# Altium schematic sheet SENSING.SchDoc (continued)

## Library data of the parts
C1 C3 C5 C6 C8 C10 C12-C14 C17 C18 C22 C23 C27 C28 = CL05A104MP5NNNC: CAP CER 0.1uF 10V X5R 20% SMD 0402 85C Paper T/R; Manufacturer: Samsung; Capacitance: 100nF; Case/Package: 0402; Case Code (Imperial): 0402; Case Code (Metric): 1005; Component Kind: Standard; ComponentLink1Description: https://media.digikey.com/pdf/Data%20Sheets/Samsung%20PDFs/CL_Series_MLCC_ds.pdf; ComponentLink1URL: https://media.digikey.com/pdf/Data%20Sheets/Samsung%20PDFs/CL_Series_MLCC_ds.pdf; Depth: 0.5mm; Dielectric: X5R; Height: 0.5mm; Lead Free: Lead Free; Length: 1mm; Library Name: EEG_SYMBOLS.SchLib; Manufacturer Part Number: CL05A104MP5NNNC; Max Operating Temperature: 85°C; Min Operating Temperature: -55°C; Mount: Surface Mount; Packaging: Tape and Reel; Pricing 1: 1=0.1, 10=0.024, 100=0.0106, 500=0.0076, 1000=0.006, 2500=0.0054, 5000=0.005 (USD); Radiation Hardening: No; Stock 1: 100000; Supplier 1: Digi-Key; Supplier Part Number 1: 1276-1443-1-ND; Thickness: 0.022inch; Tolerance: 20%; Voltage Rating (DC): 10V; Width: 0.02inch
C2 C4 C7 C9 C11 C15 C20 C21 C24 C26 C30 = CL05A105MP5NNNC: CAP CER 1uF 10V X5R 20% SMD 0402 85C Paper T/R; Manufacturer: Samsung; Capacitance: 1uF; Case/Package: 0402; Case Code (Imperial): 0402; Case Code (Metric): 1005; Component Kind: Standard; ComponentLink1Description: https://media.digikey.com/pdf/Data%20Sheets/Samsung%20PDFs/CL_Series_MLCC_ds.pdf; ComponentLink1URL: https://media.digikey.com/pdf/Data%20Sheets/Samsung%20PDFs/CL_Series_MLCC_ds.pdf; Depth: 0.5mm; Dielectric: X5R; Height: 0.5mm; Lead Free: Lead Free; Length: 1mm; Library Name: EEG_SYMBOLS.SchLib; Manufacturer Part Number: CL05A105MP5NNNC; Max Operating Temperature: 85°C; Min Operating Temperature: -55°C; Mount: Surface Mount; Package Quantity: 1; Packaging: Tape and Reel; Pricing 1: 1=0.1, 10=0.031, 100=0.014, 500=0.01, 1000=0.0079, 2500=0.0072, 5000=0.0066 (USD); Radiation Hardening: No; Stock 1: 51298; Supplier 1: Digi-Key; Supplier Part Number 1: 1276-1448-1-ND; Thickness: 0.022inch; Tolerance: 20%; Voltage Rating (DC): 10V; Width: 0.02inch
C16 C19 C25 C29 = CL21A226KPCLRNC: CAP CER 22uF 10V X5R 10% SMD 0805 85C Cardboard T/R; Manufacturer: Samsung; Capacitance: 22uF; Case/Package: 0805; Case Code (Imperial): 0805; Case Code (Metric): 2012; Component Kind: Standard; ComponentLink1Description: https://www.verical.com/server-webapp/html/getDatasheet?partID=781772; ComponentLink1URL: https://www.verical.com/server-webapp/html/getDatasheet?partID=781772; Depth: 1.25mm; Dielectric: X5R; Lead Free: Lead Free; Length: 2mm; Library Name: EEG_SYMBOLS.SchLib; Manufacturer Part Number: CL21A226KPCLRNC; Max Operating Temperature: 85°C; Min Operating Temperature: -55°C; Mount: Surface Mount; Packaging: Tape and Reel; Pricing 1: 1=0.38, 10=0.26, 100=0.1471, 500=0.1039, 1000=0.0909 (USD); Radiation Hardening: No; Stock 1: 40000; Supplier 1: Digi-Key; Supplier Part Number 1: 1276-6786-1-ND; Thickness: 0.037inch; Tolerance: 10%; Voltage Rating: 10V; Width: 0.049inch
C31 C32 = CL05C180JB5NNNC: CAP CER 18pF 50V C0G 5% SMD 0402 125C Paper T/R; Manufacturer: Samsung; Material: Ceramic; Temperature Coefficient: 300ppm/°C; Capacitance: 18pF; Case/Package: 0402; Case Code (Imperial): 0402; Case Code (Metric): 1005; Component Kind: Standard; ComponentLink1Description: https://www.verical.com/server-webapp/html/getDatasheet?partID=482699; ComponentLink1URL: https://www.verical.com/server-webapp/html/getDatasheet?partID=482699; ComponentLink2Description: https://www.distrelec.biz/Web/Downloads/_e/ng/MLCC_CatalogDownload2013_ENG.pdf?mime=application%2Fpdf; ComponentLink2URL: https://www.distrelec.biz/Web/Downloads/_e/ng/MLCC_CatalogDownload2013_ENG.pdf?mime=application%2Fpdf; ComponentLink3Description: https://produktinfo.conrad.com/datenblaetter/450000-474999/458675-da-01-en-VS_KONDENSATOR_0402.pdf; ComponentLink3URL: https://produktinfo.conrad.com/datenblaetter/450000-474999/458675-da-01-en-VS_KONDENSATOR_0402.pdf; Contact Plating: Tin; Depth: 0.5mm; Dielectric: C0G; Height: 0.5mm; Length: 1mm; Library Name: EEG_SYMBOLS.SchLib; Manufacturer Part Number: CL05C180JB5NNNC; Max Operating Temperature: 125°C; Min Operating Temperature: -55°C; Mount: Surface Mount; Package Quantity: 1; Packaging: Tape and Reel; Pricing 1: 1=0.1, 10=0.033, 100=0.0148, 500=0.0105, 1000=0.0083, 2500=0.0075, 5000=0.0069 (USD); Radiation Hardening: No; Stock 1: 42154; Supplier 1: Digi-Key; Supplier Part Number 1: 1276-1140-1-ND; Thickness: 0.022inch; Tolerance: 5%; Voltage: 50V; Voltage Rating: 50V; Width: 0.02inch
D1 D3 = LTST-C191KGKT: LED GREEN CLEAR 0603 SMD; Manufacturer: Vishay Lite-On; Dominant Wavelength: 571nm; Forward Current: 30mA; Forward Voltage: 2V; Lens Colour: Clear,Colorless; Lens Style: Clear,Diffused,Colorless,Rectangular; Luminous Intensity: 35mcd; Number of Elements: 1; Power Dissipation: 75mW; Test Current: 20mA; Viewing Angle: 130°; Wavelength: 574nm; Case/Package: 0603; Case Code (Imperial): 0603; Case Code (Metric): 1608; Colour: Green; Component Kind: Standard; ComponentLink1Description: https://datasheet.ciiva.com/12400/100-ltst-c191kgkt-53586-12400818.pdf; ComponentLink1URL: https://datasheet.ciiva.com/12400/100-ltst-c191kgkt-53586-12400818.pdf; ComponentLink2Description: https://datasheet.ciiva.com/2109/s-110-ltst-c191kgkt-2109973.pdf; ComponentLink2URL: https://datasheet.ciiva.com/2109/s-110-ltst-c191kgkt-2109973.pdf; ComponentLink3Description: https://datasheet.ciiva.com/2109/s-110-ltst-c191kgkt-2109974.pdf; ComponentLink3URL: https://datasheet.ciiva.com/2109/s-110-ltst-c191kgkt-2109974.pdf; ComponentLink4Description: https://datasheet.ciiva.com/2109/s-110-ltst-c191kgkt-2109975.pdf; ComponentLink4URL: https://datasheet.ciiva.com/2109/s-110-ltst-c191kgkt-2109975.pdf; ComponentLink5Description: https://datasheet.ciiva.com/15223/getdatasheetpartid-103913-15223276.pdf; ComponentLink5URL: https://datasheet.ciiva.com/15223/getdatasheetpartid-103913-15223276.pdf; ComponentLink6Description: https://datasheet.ciiva.com/11773/0900766b80dc02b1-11773629.pdf; ComponentLink6URL: https://datasheet.ciiva.com/11773/0900766b80dc02b1-11773629.pdf; ComponentLink7Description: https://datasheet.ciiva.com/16215/ltst-c191kgkt-16215151.pdf; ComponentLink7URL: https://datasheet.ciiva.com/16215/ltst-c191kgkt-16215151.pdf; Depth: 0.8mm; Height: 0.55mm; Illumination Colour: Green; Lead Free: Lead Free; Length: 1.6mm; Lens Transparency: Clear; Library Name: EEG_SYMBOLS.SchLib; Manufacturer Part Number: LTST-C191KGKT; Max Operating Temperature: 85°C; Min Operating Temperature: -55°C; Mount: Surface Mount; Number of LEDs: 1; Number of Pins: 2; Package Quantity: 1; Packaging: Tape and Reel; Power Rating: 75mW; Pricing 1: 1=0.29, 10=0.211, 25=0.1556, 100=0.1111, 250=0.0756, 500=0.0667, 1000=0.0522, 2500=0.0511 (USD); Radiation Hardening: No; Stock 1: 1301664; Supplier 1: Digi-Key; Supplier Part Number 1: 160-1446-6-ND; Width: 0.8mm
D2 D4 = LTST-C191TBKT-5A: LED BLUE CLEAR 0603 SMD; Manufacturer: Vishay Lite-On; Dominant Wavelength: 473nm; Forward Current: 20mA; Forward Voltage: 2.8V; Lens Colour: Colorless; Lens Style: Diffused,Colorless,Rectangular; Luminous Intensity: 15mcd; Number of LEDs: 1; Test Current: 5mA; Viewing Angle: 130°; Voltage: 29V; Case/Package: 0603; Case Code (Imperial): 0603; Case Code (Metric): 1608; Colour: Blue; Component Kind: Standard; ComponentLink1Description: http://components.arrow.com/part/dataview/52218576S9813001N1331; ComponentLink1URL: http://components.arrow.com/part/dataview/52218576S9813001N1331; ComponentLink2Description: http://optoelectronics.liteon.com/zh-cn/api/DwonloadFileHandler.ashx?txtSpecNo=DS22-2003-020&txtPartNo=LTST-C191TBKT-5A; ComponentLink2URL: http://optoelectronics.liteon.com/zh-cn/api/DwonloadFileHandler.ashx?txtSpecNo=DS22-2003-020&txtPartNo=LTST-C191TBKT-5A; Height: 0.55mm; Illumination Colour: Blue; Length: 1.6mm; Lens Transparency: Clear; Library Name: EEG_SYMBOLS.SchLib; Manufacturer Part Number: LTST-C191TBKT-5A; Max Operating Temperature: 80°C; Min Operating Temperature: -20°C; Mount: Surface Mount; Package Quantity: 5000; Packaging: Tape and Reel; Pricing 1: 1=0.52, 10=0.376, 25=0.2884, 100=0.1881, 250=0.138, 500=0.117, 1000=0.0961, 2500=0.0836 (USD); Radiation Hardening: No; Stock 1: 2918; Supplier 1: Digi-Key; Supplier Part Number 1: 160-2212-6-ND; Width: 0.8mm
J1 = CONN_10pin: 10 pin header connector; Component Kind: Standard; Library Name: EEG_SYMBOLS.SchLib; Supplier 1: Arrow; Supplier 2: Arrow; Supplier 3: Digi-Key; Supplier Part Number 1: 2698789; Supplier Part Number 2: 2698803S4475693N1004; Supplier Part Number 3: SAM1198-10-ND
J2 = 302-R161: 16 Positions Header, Shrouded Connector 0.100" (2.54mm) Through Hole, Right Angle Gold; Manufacturer: On-Shore Technology; Connector Type: Male Pin; Number of Positions: 16; Pitch: 0.1inch; Colour: Black; Component Kind: Standard; ComponentLink1Description: http://www.on-shore.com/wp-content/uploads/2015/09/302-rxxx.pdf; ComponentLink1URL: http://www.on-shore.com/wp-content/uploads/2015/09/302-rxxx.pdf; Contact Plating: Gold; Library Name: EEG_SYMBOLS.SchLib; Manufacturer Part Number: 302-R161; Mount: Through Hole; Number of Rows: 2; Packaging: Tray; Pricing 1: 1=0.44, 10=0.417, 180=0.3197, 540=0.278, 1080=0.2294, 5040=0.2016, 10080=0.1877 (USD); Row Spacing: 0.1inch; Stock 1: 7509; Supplier 1: Digi-Key; Supplier Part Number 1: ED10535-ND; Termination: Solder
J3 = M20-9990246: CONN_HeaderPins2pos Headers & Wire Housings 02 SIL VERTICAL PIN HEADER TIN; Manufacturer: Harwin; Connector Type: Male Pin; Contact Resistance: 30mR; Current Rating: 3A; Gender: Male; Insulation Resistance: 10GR; Number of Contacts: 2; Pitch: 2.54mm; Voltage Rating (AC): 750V; Case/Package: SIL; Colour: Black; Component Kind: Standard; ComponentLink1Description: https://datasheet.ciiva.com/8046/315376-8046930.pdf; ComponentLink1URL: https://datasheet.ciiva.com/8046/315376-8046930.pdf; ComponentLink2Description: https://datasheet.ciiva.com/6833/m20-999-6833368.pdf; ComponentLink2URL: https://datasheet.ciiva.com/6833/m20-999-6833368.pdf; ComponentLink3Description: https://datasheet.ciiva.com/11745/0900766b81034e61-11745755.pdf; ComponentLink3URL: https://datasheet.ciiva.com/11745/0900766b81034e61-11745755.pdf; ComponentLink4Description: https://datasheet.ciiva.com/22660/1830818-22660571.pdf; ComponentLink4URL: https://datasheet.ciiva.com/22660/1830818-22660571.pdf; ComponentLink5Description: https://datasheet.ciiva.com/26852/getdatasheetpartid-384170-26852203.pdf; ComponentLink5URL: https://datasheet.ciiva.com/26852/getdatasheetpartid-384170-26852203.pdf; Contact Material: Bronze,Brass; Contact Mating Length: 0.24inch; Contact Plating: Tin; Depth: 2.54mm; Housing Colour: Black; Housing Material: Nylon; Length: 5.08mm; Library Name: EEG_SYMBOLS.SchLib; Manufacturer Part Number: M20-9990246; Max Current Rating: 3A; Max Operating Temperature: 105°C; Min Operating Temperature: -40°C; Number of Positions: 2; Number of Rows: 1; Orientation: Straight; Package Quantity: 1000; Packaging: Bulk; Pricing 1: 1=0.11, 10=0.099, 100=0.0609, 500=0.0504, 1000=0.0441, 5000=0.0378, 10000=0.0368 (USD); Radiation Hardening: No; REACH SVHC: No SVHC; Stock 1: 53577; Supplier 1: Digi-Key; Supplier Part Number 1: 952-2262-ND; Termination: Solder
Q1-Q4 = BSS138-7-F: MOSFET N-CH 50V 200MA SOT23-3; Manufacturer: Diodes; Current: 2A; Drain to Source Resistance: 3.5R; Element Configuration: Single; Fall Time: 25ns; Gate to Source Voltage (Vgs): 20V; Input Capacitance: 50pF; Max Power Dissipation: 300mW; Nominal Vgs: 1.2V; Number of Channels: 1; Rise Time: 10ns; Turn-Off Delay Time: 20ns; Case/Package: SOT-23; Component Kind: Standard; ComponentLink1Description: http://www.diodes.com/datasheets/BSS138.pdf; ComponentLink1URL: http://www.diodes.com/datasheets/BSS138.pdf; ComponentLink2Description: http://www.farnell.com/datasheets/1915619.pdf; ComponentLink2URL: http://www.farnell.com/datasheets/1915619.pdf; ComponentLink3Description: https://www.diodes.com/assets/Datasheets/ds30144.pdf; ComponentLink3URL: https://www.diodes.com/assets/Datasheets/ds30144.pdf; ComponentLink4Description: http://www.diodes.com/_files/datasheets/ds30144.pdf; ComponentLink4URL: http://www.diodes.com/_files/datasheets/ds30144.pdf; Contact Plating: Matte,Tin; Continuous Drain Current (ID): 200mA; Current Rating: 200mA; Drain to Source Breakdown Voltage: 50V; Drain to Source Voltage (Vdss): 50V; Height: 1mm; Lead Free: Lead Free; Length: 2.9mm; Library Name: EEG_SYMBOLS.SchLib; Manufacturer Part Number: BSS138-7-F; Max Operating Temperature: 150°C; Min Operating Temperature: -55°C; Mount: Surface Mount; Number of Elements: 1; Number of Pins: 3; On-State Resistance: 3.5R; Package Quantity: 3000; Packaging: Tape and Reel; Power Dissipation: 300mW; Pricing 1: 1=0.23, 10=0.212, 100=0.119, 500=0.0637, 1000=0.0433 (USD); Rds On Max: 3.5R; REACH SVHC: No SVHC; Stock 1: 6200; Supplier 1: Digi-Key; Supplier Part Number 1: BSS138-FDICT-ND; Threshold Voltage: 1.2V; Turn-On Delay Time: 20ns; Voltage: 50V; Voltage Rating (DC): 50V; Weight: 0.000282oz; Width: 1.3mm
R1 R3 R9 R17 R22 R25 R29 R34 R36 = RC0402FR-07100KL: RES SMD 100K OHM 1% 1/16W 0402; Manufacturer: Yageo; Max Power Dissipation: 63mW; Resistance: 100kR; Temperature Coefficient: 100ppm/°C; Voltage Rating: 50V; Case/Package: 0402; Case Code (Imperial): 0402; Case Code (Metric): 1005; Component Kind: Standard; ComponentLink1Description: http://www.yageo.com/documents/recent/PYu-RC_Group_51_RoHS_L_9.pdf; ComponentLink1URL: http://www.yageo.com/documents/recent/PYu-RC_Group_51_RoHS_L_9.pdf; ComponentLink2Description: http://www.farnell.com/datasheets/1018594.pdf; ComponentLink2URL: http://www.farnell.com/datasheets/1018594.pdf; ComponentLink3Description: http://www.yageo.com/documents/recent/PYu-RC_Group_51_RoHS_L_8.pdf; ComponentLink3URL: http://www.yageo.com/documents/recent/PYu-RC_Group_51_RoHS_L_8.pdf; ComponentLink4Description: http://documents.rs-components.com/techlib://itc_doclist_GB.htm?stockno=6185797; ComponentLink4URL: http://documents.rs-components.com/techlib://itc_doclist_GB.htm?stockno=6185797; Composition: Thick Film; Depth: 0.5mm; Features: Moisture Resistant; Height: 0.016inch; Lead Free: Lead Free; Length: 0.039inch; Library Name: EEG_SYMBOLS.SchLib; Manufacturer Part Number: RC0402FR-07100KL; Max Operating Temperature: 155°C; Military Standard: Not; Min Operating Temperature: -55°C; Number of Terminations: 2; Package Quantity: 1; Packaging: Tape and Reel; Power Rating: 63mW; Pricing 1: 1=0.1, 10=0.018, 100=0.0073, 1000=0.0033, 2500=0.0029, 5000=0.0024 (USD); REACH SVHC: No SVHC; Stock 1: 16311362; Supplier 1: Digi-Key; Supplier Part Number 1: 311-100KLRCT-ND; Termination: SMD/SMT; Tolerance: 1%; Width: 0.02inch; Working Voltage: 50V
R2 R7 R16 R27 = RC0402FR-071KL: RES SMD 1K OHM 1% 1/16W 0402; Manufacturer: Yageo; Power Rating: 62.5mW; Resistance: 1kR; Temperature Coefficient: 100ppm/°C; Voltage Rating: 50V; Case/Package: 0402; Case Code (Imperial): 0402; Case Code (Metric): 1005; Component Kind: Standard; ComponentLink1Description: http://documents.rs-components.com/techlib://itc_doclist_GB.htm?stockno=6186122; ComponentLink1URL: http://documents.rs-components.com/techlib://itc_doclist_GB.htm?stockno=6186122; ComponentLink2Description: http://www.mouser.com/ds/2/447/PYu-RC0402_51_RoHS_L_6_r-8721.pdf; ComponentLink2URL: http://www.mouser.com/ds/2/447/PYu-RC0402_51_RoHS_L_6_r-8721.pdf; ComponentLink3Description: http://www.yageo.com/documents/recent/PYu-RC0402_51_RoHS_L_6_r.pdf; ComponentLink3URL: http://www.yageo.com/documents/recent/PYu-RC0402_51_RoHS_L_6_r.pdf; ComponentLink4Description: http://www.farnell.com/datasheets/1018594.pdf; ComponentLink4URL: http://www.farnell.com/datasheets/1018594.pdf; Composition: Thick Film; Depth: 0.5mm; Height: 0.32mm; Lead Free: Lead Free; Length: 1mm; Library Name: EEG_SYMBOLS.SchLib; Manufacturer Part Number: RC0402FR-071KL; Max Operating Temperature: 155°C; Military Standard: Not; Min Operating Temperature: -55°C; Number of Pins: 2; Packaging: Tape and Reel; Pricing 1: 1=0.1, 10=0.018, 100=0.0073, 1000=0.0033, 2500=0.0029, 5000=0.0024 (USD); REACH SVHC: Unknown; Stock 1: 121806164; Supplier 1: Digi-Key; Supplier Part Number 1: 311-1.00KLRCT-ND; Termination: SMD/SMT; Tolerance: 1%; Voltage Rating (DC): 50V; Width: 0.5mm
R4 R10 R19 R31 = RC0402FR-072KL: RES SMD 2K OHM 1% 1/16W 0402; Manufacturer: Yageo; Max Power Dissipation: 63mW; Resistance: 2kR; Temperature Coefficient: 100ppm/°C; Voltage Rating: 50V; Case/Package: 0402; Case Code (Imperial): 0402; Case Code (Metric): 1005; Component Kind: Standard; ComponentLink1Description: http://www.yageo.com/documents/recent/PYu-RC_Group_51_RoHS_L_9.pdf; ComponentLink1URL: http://www.yageo.com/documents/recent/PYu-RC_Group_51_RoHS_L_9.pdf; ComponentLink2Description: http://documents.rs-components.com/techlib://itc_doclist_GB.htm?stockno=6186510; ComponentLink2URL: http://documents.rs-components.com/techlib://itc_doclist_GB.htm?stockno=6186510; ComponentLink3Description: https://www.verical.com/server-webapp/html/getDatasheet?partID=358921; ComponentLink3URL: https://www.verical.com/server-webapp/html/getDatasheet?partID=358921; ComponentLink4Description: http://www.mouser.com/ds/2/447/PYu-RC0402_51_RoHS_L_6_r-8721.pdf; ComponentLink4URL: http://www.mouser.com/ds/2/447/PYu-RC0402_51_RoHS_L_6_r-8721.pdf; ComponentLink5Description: http://www.farnell.com/datasheets/1018594.pdf; ComponentLink5URL: http://www.farnell.com/datasheets/1018594.pdf; ComponentLink6Description: http://www.farnell.com/datasheets/2167052.pdf; ComponentLink6URL: http://www.farnell.com/datasheets/2167052.pdf; Composition: Thick Film; Depth: 0.5mm; Features: Moisture Resistant; Height: 0.016inch; Lead Free: Lead Free; Length: 1mm; Library Name: EEG_SYMBOLS.SchLib; Manufacturer Part Number: RC0402FR-072KL; Max Operating Temperature: 155°C; Military Standard: Not; Min Operating Temperature: -55°C; Number of Terminations: 2; Package Quantity: 1; Packaging: Tape and Reel; Power Rating: 63mW; Pricing 1: 1=0.1, 10=0.018, 100=0.0073, 1000=0.0033, 2500=0.0029, 5000=0.0024 (USD); REACH SVHC: Unknown; Stock 1: 11965319; Supplier 1: Digi-Key; Supplier Part Number 1: 311-2KLRCT-ND; Termination: SMD/SMT; Tolerance: 1%; Voltage Rating (DC): 50V; Width: 0.02inch; Working Voltage: 50V
R5 R6 R11 R12 R21 R23 R24 R32 R33 = RC0402FR-07330KL: RES SMD 330K OHM 1% 1/16W 0402; Manufacturer: Yageo; Max Power Dissipation: 63mW; Resistance: 330kR; Temperature Coefficient: 100ppm/°C; Voltage Rating: 50V; Case/Package: 0402; Case Code (Imperial): 0402; Case Code (Metric): 1005; Component Kind: Standard; ComponentLink1Description: http://www.yageo.com/documents/recent/PYu-RC_Group_51_RoHS_L_9.pdf; ComponentLink1URL: http://www.yageo.com/documents/recent/PYu-RC_Group_51_RoHS_L_9.pdf; ComponentLink2Description: http://www.mouser.com/ds/2/447/PYu-RC0402_51_RoHS_L_6_r-8721.pdf; ComponentLink2URL: http://www.mouser.com/ds/2/447/PYu-RC0402_51_RoHS_L_6_r-8721.pdf; ComponentLink3Description: http://www.farnell.com/datasheets/1018594.pdf; ComponentLink3URL: http://www.farnell.com/datasheets/1018594.pdf; ComponentLink4Description: http://www.yageo.com/documents/recent/PYu-RC0402_51_RoHS_L_6_r.pdf; ComponentLink4URL: http://www.yageo.com/documents/recent/PYu-RC0402_51_RoHS_L_6_r.pdf; Composition: Thick Film; Depth: 0.5mm; Features: Moisture Resistant; Height: 0.016inch; Lead Free: Lead Free; Length: 1mm; Library Name: EEG_SYMBOLS.SchLib; Manufacturer Part Number: RC0402FR-07330KL; Max Operating Temperature: 155°C; Military Standard: Not; Min Operating Temperature: -55°C; Number of Terminations: 2; Package Quantity: 1; Packaging: Tape and Reel; Power Rating: 63mW; Pricing 1: 1=0.1, 10=0.018, 100=0.0073, 1000=0.0033, 2500=0.0029, 5000=0.0024 (USD); REACH SVHC: Unknown; Stock 1: 1854774; Supplier 1: Digi-Key; Supplier Part Number 1: 311-330KLRCT-ND; Termination: SMD/SMT; Tolerance: 1%; Width: 0.02inch
R8 R14 R15 R20 R26 R30 R35 R38 = RC0402FR-071ML: RES SMD 1M OHM 1% 1/16W 0402; Manufacturer: Yageo; Power Rating: 63mW; Resistance: 1MR; Temperature Coefficient: 100ppm/°C; Voltage Rating: 50V; Case/Package: 0402; Case Code (Imperial): 0402; Case Code (Metric): 1005; Component Kind: Standard; ComponentLink1Description: http://www.farnell.com/datasheets/1018594.pdf; ComponentLink1URL: http://www.farnell.com/datasheets/1018594.pdf; ComponentLink2Description: http://www.yageo.com/documents/recent/PYu-RC0402_51_RoHS_L_6_r.pdf; ComponentLink2URL: http://www.yageo.com/documents/recent/PYu-RC0402_51_RoHS_L_6_r.pdf; Composition: Thick Film; Depth: 0.5mm; Height: 0.32mm; Lead Free: Lead Free; Length: 1mm; Library Name: EEG_SYMBOLS.SchLib; Manufacturer Part Number: RC0402FR-071ML; Max Operating Temperature: 155°C; Military Standard: Not; Min Operating Temperature: -55°C; Packaging: Tape and Reel; Pricing 1: 1=0.1, 10=0.018, 100=0.0073, 1000=0.0033, 2500=0.0029, 5000=0.0024 (USD); REACH SVHC: Unknown; Stock 1: 18919667; Supplier 1: Digi-Key; Supplier Part Number 1: 311-1.00MLRCT-ND; Termination: SMD/SMT; Tolerance: 1%
R13 R18 R28 R37 = RMCF0402FT499K: RES SMD 499K 0402 1% 1/16W 100ppm/C Molded SMD Paper T/R; Manufacturer: Stackpole Electronics; Max Power Dissipation: 63mW; Resistance: 499kR; Temperature Coefficient: 100ppm/°C; Case/Package: 0402; Case Code (Imperial): 0402; Case Code (Metric): 1005; Component Kind: Standard; Composition: Thick Film; Contact Plating: Matte,Tin; Features: Automotive AEC-Q200; Height: 0.016inch; Lead Free: Lead Free; Length: 0.039inch; Library Name: EEG_SYMBOLS.SchLib; Manufacturer Part Number: RMCF0402FT499K; Max Operating Temperature: 155°C; Min Operating Temperature: -55°C; Mount: Surface Mount; Number of Terminations: 2; Package Quantity: 10000; Packaging: Tape and Reel; Pricing 1: 1=0.1, 10=0.016, 100=0.0064, 1000=0.0029, 2500=0.0025, 5000=0.0021 (USD); Stock 1: 80000; Supplier 1: Digi-Key; Supplier Part Number 1: RMCF0402FT499KCT-ND; Tolerance: 1%; Width: 0.02inch
R39-R46 = RC0402JR-0710KL: RES SMD 10K OHM 5% 1/16W 0402; Manufacturer: Yageo; Max Power Dissipation: 63mW; Power Rating: 62.5mW; Resistance: 10kR; Temperature Coefficient: 100ppm/°C; Voltage Rating: 50V; Case/Package: 0402; Case Code (Imperial): 0402; Case Code (Metric): 1005; Component Kind: Standard; Composition: Thick Film; Depth: 0.5mm; Features: Moisture Resistant; Height: 0.016inch; Lead Free: Lead Free; Length: 1mm; Library Name: EEG_SYMBOLS.SchLib; Manufacturer Part Number: RC0402JR-0710KL; Max Operating Temperature: 155°C; Military Standard: Not; Min Operating Temperature: -55°C; Number of Terminations: 2; Package Quantity: 1; Packaging: Tape and Reel; Pricing 1: 1=0.1, 10=0.018, 100=0.0073, 1000=0.0033, 2500=0.0029, 5000=0.0024 (USD); REACH SVHC: Unknown; Stock 1: 47303622; Supplier 1: Digi-Key; Supplier Part Number 1: 311-10KJRCT-ND; Termination: SMD/SMT; Tolerance: 5%; Width: 0.02inch
R47 = RC0805FR-07100RL: RES SMD 100 OHM 1% 1/8W 0805; Manufacturer: Yageo; Max Power Dissipation: 125mW; Temperature Coefficient: 100ppm/°C; Voltage Rating: 150V; Case/Package: 0805; Case Code (Imperial): 0805; Case Code (Metric): 2012; Component Kind: Standard; ComponentLink1Description: http://www.farnell.com/datasheets/1697719.pdf; ComponentLink1URL: http://www.farnell.com/datasheets/1697719.pdf; ComponentLink2Description: http://www.yageo.ru/pdf/RC0805.pdf; ComponentLink2URL: http://www.yageo.ru/pdf/RC0805.pdf; ComponentLink3Description: http://www.farnell.com/datasheets/444164.pdf; ComponentLink3URL: http://www.farnell.com/datasheets/444164.pdf; ComponentLink4Description: http://www.yageo.com/documents/recent/PYu-RC_Group_51_RoHS_L_9.pdf; ComponentLink4URL: http://www.yageo.com/documents/recent/PYu-RC_Group_51_RoHS_L_9.pdf; ComponentLink5Description: http://www.farnell.com/datasheets/38627.pdf; ComponentLink5URL: http://www.farnell.com/datasheets/38627.pdf; ComponentLink6Description: http://www.farnell.com/datasheets/1686128.pdf; ComponentLink6URL: http://www.farnell.com/datasheets/1686128.pdf; Composition: Thick Film; Depth: 1.25mm; Features: Moisture Resistant; Height: 0.024inch; Lead Free: Lead Free; Lead Pitch: 0.4mm; Length: 2mm; Library Name: EEG_SYMBOLS.SchLib; Manufacturer Part Number: RC0805FR-07100RL; Max Operating Temperature: 155°C; Military Standard: Not; Min Operating Temperature: -55°C; Number of Pins: 2; Number of Terminations: 2; Package Quantity: 1; Packaging: Tape and Reel; Power Rating: 125mW; Pricing 1: 1=0.1, 10=0.046, 100=0.0186, 1000=0.0084, 2500=0.0073 (USD); REACH SVHC: Unknown; Resistance: 100R; Stock 1: 12008452; Supplier 1: Digi-Key; Supplier Part Number 1: 311-100CRCT-ND; Termination: SMD/SMT; Tolerance: 1%; Voltage Rating (DC): 150V; Width: 0.049inch
R48-R51 = RC0402JR-070RL: RES SMD 0 OHM 1/16W 0402; Manufacturer: Yageo; Max Power Dissipation: 63mW; Power Rating: 62.5mW; Resistance: 0mR; Temperature Coefficient: 200ppm/°C; Tolerance: 5%; Voltage Rating: 50V; Case/Package: 0402; Case Code (Imperial): 0402; Case Code (Metric): 1005; Component Kind: Standard; Composition: Thick Film; Contact Plating: Matte,Tin; Depth: 0.5mm; Features: Moisture Resistant; Height: 0.016inch; Lead Free: Lead Free; Length: 1mm; Library Name: EEG_SYMBOLS.SchLib; Manufacturer Part Number: RC0402JR-070RL; Max Operating Temperature: 155°C; Military Standard: Not; Min Operating Temperature: -55°C; Number of Terminations: 2; Package Quantity: 1; Packaging: Tape and Reel; Pricing 1: 1=0.1, 10=0.017, 25=0.0124, 50=0.0096, 100=0.007, 250=0.0054, 500=0.0043, 1000=0.0032, 5000=0.0023 (USD); Radiation Hardening: No; REACH SVHC: Unknown; Stock 1: 44333412; Supplier 1: Digi-Key; Supplier Part Number 1: 311-0.0JRCT-ND; Termination: SMD/SMT; Width: 0.02inch
R52-R55 = ERJ-2RKF3000X: RES SMD 300R 0402 300 Ohm 1% 1/10W ±100ppm/C Molded SMD Punched Carrier T/R; Manufacturer: Panasonic; Max Power Dissipation: 100mW; Temperature Coefficient: 100ppm/°C; Voltage Rating: 50V; Case/Package: 0402; Case Code (Imperial): 0402; Case Code (Metric): 1005; Component Kind: Standard; ComponentLink1Description: https://www.alliedelec.com/m/d/623f45ff1957d936ff56d46fd1c28cac.pdf; ComponentLink1URL: https://www.alliedelec.com/m/d/623f45ff1957d936ff56d46fd1c28cac.pdf; ComponentLink2Description: http://industrial.panasonic.com/www-data/pdf/AOA0000/AOA0000CE2.pdf; ComponentLink2URL: http://industrial.panasonic.com/www-data/pdf/AOA0000/AOA0000CE2.pdf; ComponentLink3Description: http://www.farnell.com/datasheets/1911175.pdf; ComponentLink3URL: http://www.farnell.com/datasheets/1911175.pdf; ComponentLink4Description: http://components.arrow.com/part/dataview/41791037S8289418N1386; ComponentLink4URL: http://components.arrow.com/part/dataview/41791037S8289418N1386; ComponentLink5Description: http://www.mouser.com/ds/2/315/AOA0000CE2-37512.pdf; ComponentLink5URL: http://www.mouser.com/ds/2/315/AOA0000CE2-37512.pdf; ComponentLink6Description: http://www.farnell.com/datasheets/1694929.pdf; ComponentLink6URL: http://www.farnell.com/datasheets/1694929.pdf; Composition: Thick Film; Contact Plating: Tin; Depth: 0.5mm; Height: 0.35mm; Lead Free: Lead Free; Length: 1mm; Library Name: EEG_SYMBOLS.SchLib; Manufacturer Part Number: ERJ-2RKF3000X; Max Operating Temperature: 155°C; Military Standard: Not; Min Operating Temperature: -55°C; Mount: Surface Mount; Number of Terminations: 2; Package Quantity: 10000; Packaging: Tape and Reel; Power Rating: 100mW; Pricing 1: 1=0.1, 10=0.054, 100=0.0221, 1000=0.0099, 2500=0.0086, 5000=0.0071 (USD); REACH SVHC: No SVHC; Resistance: 300R; Stock 1: 2431619; Supplier 1: Digi-Key; Supplier Part Number 1: P300LCT-ND; Termination: SMD/SMT; Tolerance: 1%; Voltage Rating (DC): 50V; Width: 0.02inch
U1 U3 = NCP551SN30T1G: LDO 3.0V 150mA CMOS w/Enable; Manufacturer: ON Semiconductor; Accuracy: 2%; Dropout Voltage: 40mV; Input Bias Current: 4uA; Max Input Voltage: 12V; Min Input Voltage: 0uV; Min Output Voltage: 3V; Number of Outputs: 1; Output Type: Fixed; Polarity: Positive; Quiescent Current: 8uA; Case/Package: TSOP; Component Kind: Standard; ComponentLink1Description: http://www.onsemi.ru.com/pub/Collateral/NCP551-D.PDF; ComponentLink1URL: http://www.onsemi.ru.com/pub/Collateral/NCP551-D.PDF; ComponentLink2Description: http://www.onsemi.com/pub/Collateral/NCP551-D.PDF; ComponentLink2URL: http://www.onsemi.com/pub/Collateral/NCP551-D.PDF; Contact Plating: Matte,Tin; Halogen Free: Halogen Free; Height: 1mm; Lead Free: Lead Free; Length: 3mm; Library Name: EEG_SYMBOLS.SchLib; Manufacturer Part Number: NCP551SN30T1G; Max Operating Temperature: 85°C; Max Output Current: 150mA; Min Operating Temperature: -40°C; Mount: Surface Mount; Nominal Output Voltage: 3V; Number of Pins: 5; Number of Regulators: 1; Output Current: 150mA; Output Voltage: 3V; Output Voltage Accuracy: 2%; Package Quantity: 1; Packaging: Tape and Reel; Pricing 1: 1=0.54, 10=0.46, 100=0.3432, 500=0.2697, 1000=0.2084 (USD); Radiation Hardening: No; REACH SVHC: No SVHC; Stock 1: 9920; Supplier 1: Digi-Key; Supplier Part Number 1: NCP551SN30T1GOSCT-ND; Width: 1.5mm
U2 = MCP3912A1-E/SS: IC Analog Front End - AFE 4 Chnl Energy Meter Front End 3V SPI/2; Manufacturer: Microchip; Interface: Serial,SPI; Max Supply Voltage: 3.6V; Min Supply Voltage: 2.7V; Number of A/D Converters: 4; Resolution: 24b; Sampling Rate: 125KS/s; Case/Package: SSOP; Component Kind: Standard; Height: 1.85mm; Lead Free: Lead Free; Length: 10.5mm; Library Name: EEG_SYMBOLS.SchLib; Manufacturer Part Number: MCP3912A1-E/SS; Max Operating Temperature: 125°C; Min Operating Temperature: -40°C; Mount: Surface Mount; Number of Channels: 4; Number of Pins: 28; Package Quantity: 47; Packaging: Rail/Tube; Pricing 1: 1=3.33, 25=2.7708, 100=2.5235 (USD); REACH SVHC: No SVHC; Stock 1: 156; Supplier 1: Digi-Key; Supplier Part Number 1: MCP3912A1-E/SS-ND; Width: 5.6mm
U4 U6 U9 U12 = AD8237ARMZ-R7: IC OPAMP INSTR 200KHZ RRO 8MSOP; Manufacturer: Analog Devices; Bandwidth: 20kHz; Common Mode Rejection Ratio: 106dB; Input Bias Current: 250pA; Input Offset Voltage (Vos): 75uV; Max Supply Voltage: 5.5V; Min Supply Voltage: 1.8V; Nominal Supply Current: 115uA; Number of Channels: 1; Output Current per Channel: 4mA; Slew Rate: 0.15V/µs; Voltage Gain: 60dB; -3db Bandwidth: 200kHz; Case/Package: MSOP; Component Kind: Standard; ComponentLink1Description: http://www.analog.com/media/en/technical-documentation/data-sheets/AD8237.pdf; ComponentLink1URL: http://www.analog.com/media/en/technical-documentation/data-sheets/AD8237.pdf; ComponentLink2Description: http://www.analog.com/static/imported-files/data_sheets/AD8237.pdf; ComponentLink2URL: http://www.analog.com/static/imported-files/data_sheets/AD8237.pdf; Contact Plating: Matte,Tin; Lead Free: Contains Lead; Library Name: EEG_SYMBOLS.SchLib; Manufacturer Part Number: AD8237ARMZ-R7; Max Operating Temperature: 125°C; Min Operating Temperature: -40°C; Mount: Surface Mount; Number of Circuits: 1; Number of Elements: 1; Number of Pins: 8; Operating Supply Current: 115uA; Package Quantity: 1; Packaging: Tape and Reel; Pricing 1: 1=2.76, 10=2.476, 100=2.0286, 500=1.7269 (USD); Stock 1: 16545; Supplier 1: Digi-Key; Supplier Part Number 1: AD8237ARMZ-R7CT-ND
U5 = LM7332MAX/NOPB: IC OP AMP R-R I/O DUAL 30V 8SOIC; Manufacturer: TI National Semiconductor; Common Mode Rejection Ratio: 62dB; Gain Bandwidth Product: 21MHz; Input Bias Current: 1uA; Input Offset Voltage (Vos): 2mV; Max Dual Supply Voltage: 16V; Max Supply Voltage: 32V; Min Dual Supply Voltage: 1.25V; Min Supply Voltage: 2.5V; Nominal Supply Current: 2mA; Number of Channels: 2; Output Current: 70mA; Power Supply Rejection Ratio (PSRR): 78dB; Slew Rate: 15.2V/µs; Unity Gain Bandwidth Product: 19.3MHz; Voltage Gain: 77dB; Case/Package: SOIC; Component Kind: Standard; ComponentLink1Description: http://www.ti.com/lit/ds/symlink/lm7332.pdf; ComponentLink1URL: http://www.ti.com/lit/ds/symlink/lm7332.pdf; Contact Plating: Tin; Lead Free: Lead Free; Library Name: EEG_SYMBOLS.SchLib; Manufacturer Part Number: LM7332MAX/NOPB; Max Operating Temperature: 125°C; Min Operating Temperature: -40°C; Mount: Surface Mount; Number of Circuits: 2; Number of Elements: 2; Number of Pins: 8; Operating Supply Current: 2mA; Operating Supply Voltage: 16V; Output Current per Channel: 70mA; Package Quantity: 2500; Packaging: Tape and Reel; Pricing 1: 1=2.67, 10=2.402, 100=1.9306, 500=1.5862, 1000=1.3143 (USD); Radiation Hardening: No; Stock 1: 7321; Supplier 1: Digi-Key; Supplier Part Number 1: 296-35310-1-ND
U7 U8 U10 U11 U13 = MCP6V31T-E/OT: IC OPAMP ZRO-DRFT 300KHZ SOT23-5; Manufacturer: Microchip; Common Mode Rejection Ratio: 120dB; Input Bias Current: 5pA; Input Offset Voltage (Vos): 8uV; Max Supply Voltage: 5.5V; Min Supply Voltage: 1.8V; Nominal Supply Current: 23uA; Number of Amplifiers: 1; Output Current: 21mA; Slew Rate: 0.13V/µs; Voltage Gain: 135dB; Bandwidth: 300kHz; Case/Package: SOT-23; Component Kind: Standard; ComponentLink1Description: http://ww1.microchip.com/downloads/en/DeviceDoc/25127A.pdf; ComponentLink1URL: http://ww1.microchip.com/downloads/en/DeviceDoc/25127A.pdf; ComponentLink2Description: https://www.distrelec.biz/Web/Downloads/_t/ds/MCP6V31_1U_eng_tds.pdf?mime=application%2Fpdf; ComponentLink2URL: https://www.distrelec.biz/Web/Downloads/_t/ds/MCP6V31_1U_eng_tds.pdf?mime=application%2Fpdf; ComponentLink3Description: https://www.verical.com/server-webapp/html/getDatasheet?partID=762349; ComponentLink3URL: https://www.verical.com/server-webapp/html/getDatasheet?partID=762349; ComponentLink4Description: http://ww1.microchip.com/downloads/jp/DeviceDoc/jp557758.pdf; ComponentLink4URL: http://ww1.microchip.com/downloads/jp/DeviceDoc/jp557758.pdf; ComponentLink5Description: http://www.microchip.com/mymicrochip/filehandler.aspx?ddocname=en557758; ComponentLink5URL: http://www.microchip.com/mymicrochip/filehandler.aspx?ddocname=en557758; ComponentLink6Description: http://www.farnell.com/datasheets/1599560.pdf; ComponentLink6URL: http://www.farnell.com/datasheets/1599560.pdf; Gain Bandwidth Product: 300kHz; Height: 1.3mm; Lead Free: Lead Free; Length: 3.1mm; Library Name: EEG_SYMBOLS.SchLib; Manufacturer Part Number: MCP6V31T-E/OT; Max Operating Temperature: 125°C; Min Operating Temperature: -40°C; Number of Channels: 1; Number of Circuits: 1; Number of Elements: 1; Number of Pins: 5; Operating Supply Current: 23uA; Output Current per Channel: 21mA; Package Quantity: 3000; Packaging: Tape and Reel; Power Supply Rejection Ratio (PSRR): 120dB; Pricing 1: 1=1.11, 25=0.9272, 100=0.8446 (USD); Radiation Hardening: No; REACH SVHC: No SVHC; Stock 1: 12497; Supplier 1: Digi-Key; Supplier Part Number 1: MCP6V31T-E/OTCT-ND; Unity Gain Bandwidth Product: 300kHz; Width: 1.8mm
U14 = LM334MX/NOPB: IC CURRENT SOURCE 3% 8SOIC; Manufacturer: TI National Semiconductor; Accuracy: 6%; Forward Current: 5mA; Forward Voltage: 1V; Max Input Voltage: 40V; Max Power Dissipation: 400mW; Max Supply Current: 10mA; Min Supply Voltage: 800mV; Number of Outputs: 1; Output Type: Analog; Output Voltage: 20V; Quiescent Current: 1uA; Reference Type: Adjustable; Case/Package: SOIC; Component Kind: Standard; ComponentLink1Description: https://www.verical.com/server-webapp/html/getDatasheet?partID=629166; ComponentLink1URL: https://www.verical.com/server-webapp/html/getDatasheet?partID=629166; ComponentLink2Description: http://www.ti.com/lit/ds/symlink/lm234.pdf; ComponentLink2URL: http://www.ti.com/lit/ds/symlink/lm234.pdf; ComponentLink3Description: http://www.ti.com/general/docs/lit/getliterature.tsp?genericPartNumber=LM334&&fileType=pdf; ComponentLink3URL: http://www.ti.com/general/docs/lit/getliterature.tsp?genericPartNumber=LM334&&fileType=pdf; ComponentLink4Description: http://www.farnell.com/datasheets/1883087.pdf; ComponentLink4URL: http://www.farnell.com/datasheets/1883087.pdf; Lead Free: Lead Free; Library Name: EEG_SYMBOLS.SchLib; Manufacturer Part Number: LM334MX/NOPB; Max Operating Temperature: 85°C; Max Supply Voltage: 40V; Min Input Voltage: 1V; Min Operating Temperature: -40°C; Mount: Surface Mount; Number of Pins: 8; Output Current: 10mA; Package Quantity: 2500; Packaging: Tape and Reel; Pricing 1: 1=0.9, 10=0.802, 100=0.6254, 500=0.5166, 1000=0.4079 (USD); Radiation Hardening: No; REACH SVHC: No SVHC; Stock 1: 58254; Supplier 1: Digi-Key; Supplier Part Number 1: LM334MX/NOPBCT-ND
U15 = MCP4011-103E/SN: IC 10K Digital Potentiometer Single, 2 Wire, Serial,  20%, 1.8 V; Manufacturer: Microchip; Interface: 2-Wire,Serial; Max Supply Current: 45uA; Max Supply Voltage: 5.5V; Memory Type: Volatile; Min Supply Voltage: 1.8V; Number of Circuits: 1; Number of Positions: 64; Operating Supply Voltage: 5V; Resistance: 10kR; Temperature Coefficient: 150ppm/°C; Case/Package: SOIC; Component Kind: Standard; ComponentLink1Description: http://ww1.microchip.com/downloads/en/DeviceDoc/21978c.pdf; ComponentLink1URL: http://ww1.microchip.com/downloads/en/DeviceDoc/21978c.pdf; ComponentLink2Description: https://www.verical.com/server-webapp/html/getDatasheet?partID=431510; ComponentLink2URL: https://www.verical.com/server-webapp/html/getDatasheet?partID=431510; ComponentLink3Description: http://www.microchip.com/mymicrochip/filehandler.aspx?ddocname=en025230; ComponentLink3URL: http://www.microchip.com/mymicrochip/filehandler.aspx?ddocname=en025230; ComponentLink4Description: http://www.microchip.com/mymicrochip/filehandler.aspx?ddocname=en023833; ComponentLink4URL: http://www.microchip.com/mymicrochip/filehandler.aspx?ddocname=en023833; ComponentLink5Description: https://www.distrelec.biz/Web/Downloads/_t/ds/mcp4011_eng_tds.pdf?mime=application%2Fpdf; ComponentLink5URL: https://www.distrelec.biz/Web/Downloads/_t/ds/mcp4011_eng_tds.pdf?mime=application%2Fpdf; ComponentLink6Description: http://www.farnell.com/datasheets/130143.pdf; ComponentLink6URL: http://www.farnell.com/datasheets/130143.pdf; Contact Plating: Matte,Tin; Height: 1.55mm; Lead Free: Lead Free; Length: 5mm; Library Name: EEG_SYMBOLS.SchLib; Manufacturer Part Number: MCP4011-103E/SN; Max Operating Temperature: 125°C; Min Operating Temperature: -40°C; Mount: Surface Mount; Nominal Supply Current: 45uA; Number of Elements: 1; Number of Pins: 8; Number of Taps: 64; Package Quantity: 100; Packaging: Rail/Tube; Pricing 1: 1=0.53, 25=0.4428, 100=0.4017 (USD); Radiation Hardening: No; REACH SVHC: No SVHC; Stock 1: 1448; Supplier 1: Digi-Key; Supplier Part Number 1: MCP4011-103E/SN-ND; Taper: Linear; Tolerance: 20%; Width: 3.99mm
U16-U18 = TPD4E1U06DBVR: IC ESD Suppressor Diode Arrays 5.5V 6-Pin SOT-23 T/R; Manufacturer: Texas Instruments; Breakdown Voltage: 6.5V; Capacitance: 1pF; Clamping Voltage: 15V; Direction: Unidirectional; ESD Protection: Yes; Interface: Ethernet,LVDS,USB; Leakage Current: 10nA; Max Power Dissipation: 45W; Number of Bidirectional Channels: 4; Peak Pulse Current: 3A; Polarity: Bidirectional; Test Current: 1mA; Case/Package: SOT-23; Component Kind: Standard; ComponentLink1Description: https://www.verical.com/server-webapp/html/getDatasheet?partID=716791; ComponentLink1URL: https://www.verical.com/server-webapp/html/getDatasheet?partID=716791; ComponentLink2Description: http://www.ti.com/lit/ds/symlink/tpd4e1u06.pdf; ComponentLink2URL: http://www.ti.com/lit/ds/symlink/tpd4e1u06.pdf; Depth: 1.75mm; Height: 1.3mm; Lead Free: Lead Free; Length: 3.05mm; Library Name: EEG_SYMBOLS.SchLib; Manufacturer Part Number: TPD4E1U06DBVR; Max Operating Temperature: 125°C; Max Reverse Leakage Current: 10nA; Min Breakdown Voltage: 6.5V; Min Operating Temperature: -40°C; Mount: Surface Mount; Number of Channels: 4; Number of Pins: 6; Operating Supply Voltage: 5.5V; Package Quantity: 3000; Packaging: Tape and Reel; Peak Pulse Power: 45W; Power Line Protection: Yes; Pricing 1: 1=0.43, 10=0.346, 100=0.2353, 500=0.1765, 1000=0.1324 (USD); Radiation Hardening: No; REACH SVHC: No SVHC; Reverse Standoff Voltage: 5.5V; Stock 1: 155098; Supplier 1: Digi-Key; Supplier Part Number 1: 296-35965-1-ND; Termination: SMD/SMT; Width: 1.75mm; Working Voltage: 5.5V
XTAL = ABLS-4.000MHZ-B2-T: 4MHz ±20ppm Crystal 18pF 180 Ohm -20°C ~ 70°C  Surface Mount HC49/US; Manufacturer: Abracon; ESR (Equivalent Series Resistance): 180R; Frequency Stability: 50ppm; Case/Package: SMD/SMT; Component Kind: Standard; ComponentLink1Description: https://abracon.com/Support/PackageDrawing/Resonators/ABLS-2D.pdf; ComponentLink1URL: https://abracon.com/Support/PackageDrawing/Resonators/ABLS-2D.pdf; ComponentLink2Description: http://www.abracon.com/Resonators/abls.pdf; ComponentLink2URL: http://www.abracon.com/Resonators/abls.pdf; ComponentLink3Description: https://www.verical.com/server-webapp/html/getDatasheet?partID=722412; ComponentLink3URL: https://www.verical.com/server-webapp/html/getDatasheet?partID=722412; ComponentLink4Description: http://www.mouser.com/ds/2/3/abls-44621.pdf; ComponentLink4URL: http://www.mouser.com/ds/2/3/abls-44621.pdf; Depth: 4.7mm; Frequency: 4MHz; Frequency Tolerance: 20ppm; Height: 0.165inch; Lead Free: Lead Free; Length: 11.4mm; Library Name: EEG_SYMBOLS.SchLib; Load Capacitance: 18pF; Manufacturer Part Number: ABLS-4.000MHZ-B2-T; Max Operating Temperature: 70°C; Min Operating Temperature: -20°C; Mount: Surface Mount; Number of Pins: 2; Package Quantity: 1000; Packaging: Tape and Reel; Pricing 1: 1=0.28, 10=0.234, 50=0.2104, 100=0.187, 500=0.1777 (USD); Radiation Hardening: No; REACH SVHC: Yes; Series Resistance: 180R; Stock 1: 74308; Supplier 1: Digi-Key; Supplier Part Number 1: 535-9085-1-ND; Termination: SMD/SMT; Tolerance: 20ppm; Width: 0.185inch
 = NETTIE: Component Kind: Net Tie; Library Name: EEG_SYMBOLS.SchLib
